# Altium schematic sheet POWER_12OUT_CH1 不焊接.SchDoc
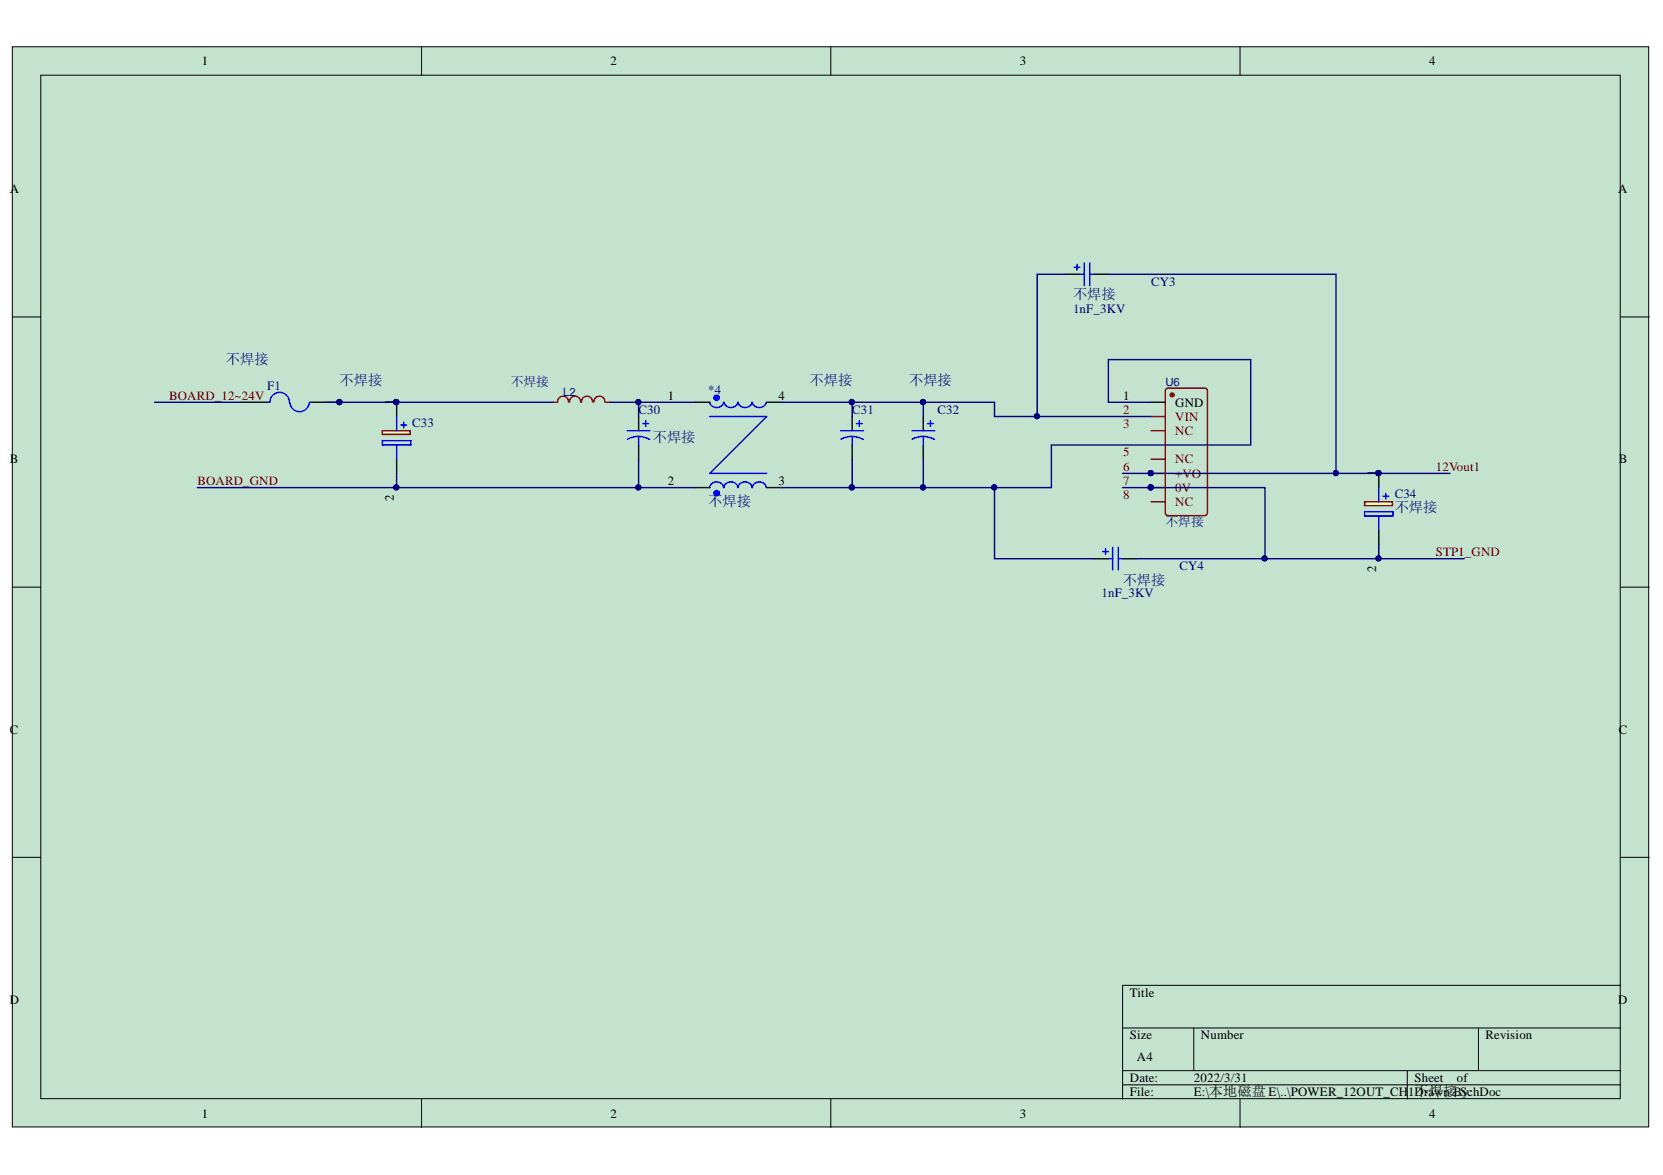
[diagram p0: POWER_12OUT_CH1 不焊接.SchDoc]

## Parts
*4  不焊接  [FL2D-XX-XXX]
C30  不焊接  [C1210_N]
C31  不焊接  [C1210_N]
C32  不焊接  [EEE0JA152UAP]
C33  不焊接  [CAP12.5*25]
C34  不焊接  [CAPPRD200W55D500H1200]
CY3  不焊接  value 1nF_3KV  [CAP1808]
CY4  不焊接  value 1nF_3KV  [CAP1808]
F1  不焊接  [1206_FUSE]
L2  不焊接  [IND-SMD_L5.2-W5.2_MS0530-XX]
U6  不焊接  [PWRM-TH_UWFXXXXS-3WR3]

## Nets
12VOUT1: C34.1 CY3.2 U6.6(+VO)
BOARD_12~24V: F1.1
BOARD_GND: *4.2 C30.2 C33.2
STP1_GND: C34.2 CY4.2 U6.7(0V)
Net*4_1: *4.1 C30.1 L2.2
Net*4_3: *4.3 C31.2 C32.2 CY4.1 U6.1(GND)
Net*4_4: *4.4 C31.1 C32.1 CY3.1 U6.2(VIN)
NetC33_1: C33.1 F1.2 L2.1

Not connected: U6 3(NC) 5(NC) 8(NC)

## Library data of the parts
*4 = FL2D: Component Kind: Standard; Library Name: Schlib1.SchLib
C30-C32 = Cap Pol2: Polarized Capacitor (Axial); Value: 10uF; Component Kind: Standard; Library Name: Miscellaneous Devices.IntLib; Published: 8-Jun-2000; Revision: July-2002: Re-released for DXP Platform.; Signal Integrity: Cap Pol; Simulation: CAP; Publisher: Altium Limited
C33 = ECA-1HHG102: Capacitor Polarised; Manufacturer_Name: Panasonic; Component Kind: Standard; Datasheet Link: https://componentsearchengine.com//ECA-1HHG102.pdf; Height: 27mm; Manufacturer_Part_Number: ECA-1HHG102; Mouser2 Part Number: 667-ECA-1HHG102; Mouser2 Price/Stock: https://www.mouser.com/Search/Refine.aspx?Keyword=667-ECA-1HHG102
C34 = ECA-1HHG220I: Capacitor Polarised; Manufacturer_Name: Panasonic; Component Kind: Standard; Datasheet Link: http://industrial.panasonic.com/cdbs/www-data/pdf/RDF0000/ABA0000C1215.pdf; Height: 12mm; Manufacturer_Part_Number: ECA-1HHG220I
CY3 CY4 = Cap Pol2: Polarized Capacitor (Axial); Component Kind: Standard; LatestRevisionDate: 17-Jul-2002; LatestRevisionNote: Re-released for DXP Platform.; Library Name: Miscellaneous Devices.IntLib; PackageDescription: Polarized Capacitor; 2 Leads; PackageReference: POLAR0.8; Published: 8-Jun-2000; Signal Integrity: Cap Pol; Simulation: CAP; Publisher: Altium Limited
F1 = Fuse 2: Fuse; Component Kind: Standard; LatestRevisionDate: 17-Jul-2002; LatestRevisionNote: Re-released for DXP Platform.; PackageReference: PIN-W2/E2.8; Published: 8-Jun-2000; Simulation: FUSE; Library Name: jinrylib.IntLib; Publisher: Altium Limited
L2 = 4.7uH: BOM_Manufacturer: COILMX; BOM_Manufacturer Part: MS0530-4R7M; Contributor: LCSC; nameAlias: Inductance; BOM_JLCPCB Part Class: Extended Part; BOM_Supplier: LCSC; BOM_Supplier Part: C380165; Component Kind: Standard; Library Name: Schlib1.SchLib; spicePre: L; spiceSymbolName: MS0530-4R7M
U6 = UWF1212S-3WR3: BOM_Manufacturer: MORNSUN(金升阳); Contributor: LCSC; BOM_JLCPCB Part Class: Extended Part; BOM_Manufacturer Part: UWF1212S-3WR3; BOM_Supplier: LCSC; BOM_Supplier Part: C2684865; Component Kind: Standard; Library Name: Schlib1.SchLib; spicePre: U; spiceSymbolName: UWF1212S-3WR3
